# Altium schematic sheet Main_Board_NFC.SchDoc
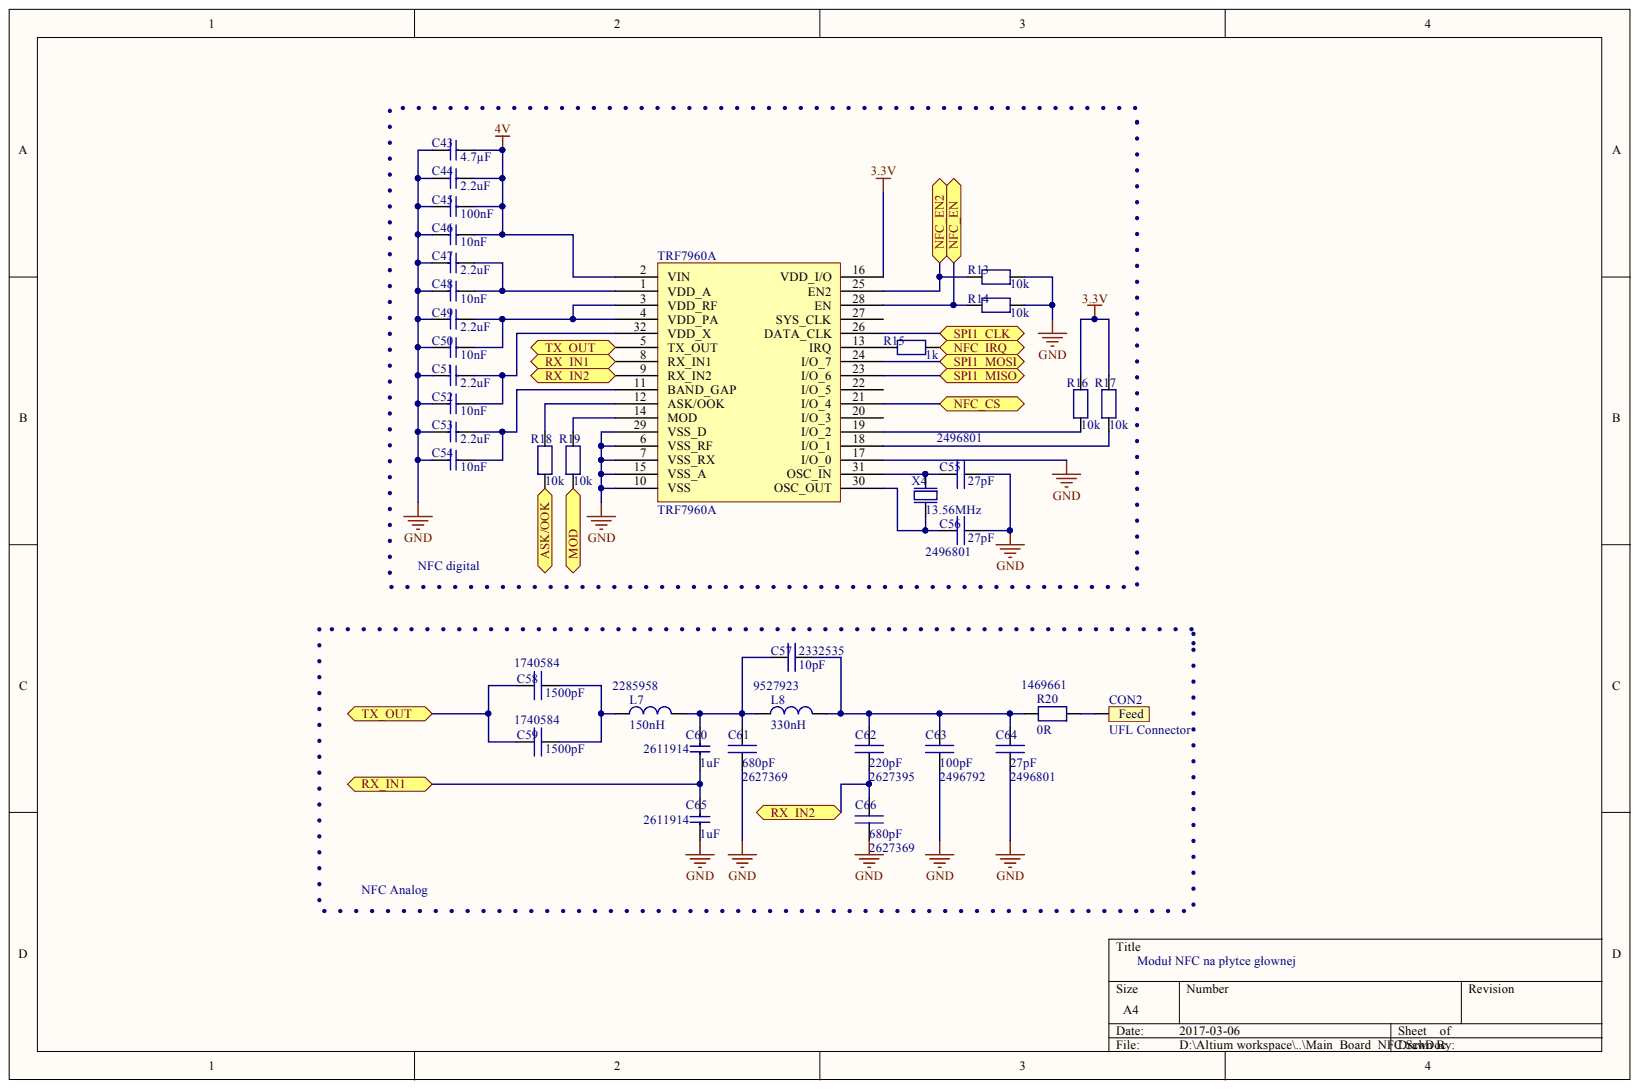
[diagram p0: Main_Board_NFC.SchDoc]

## Parts
C43  4.7µF  [CAPC1608X06L]
C44  2.2uF  value 4.7µF  [CAPC1608X06L]
C45  100nF  [CAPC0603X03L]
C46  10nF  value 100nF  [CAPC0603X03L]
C47  2.2uF  [CAPC1608X06L]
C48  10nF  [CAPC0603X03L]
C49  2.2uF  [CAPC1608X06L]
C50  10nF  [CAPC0603X03L]
C51  2.2uF  [CAPC1608X06L]
C52  10nF  [CAPC0603X03L]
C53  2.2uF  [CAPC1608X06L]
C54  10nF  [CAPC0603X03L]
C55  27pF  Supplier Part Number 1: 2496801  [0402]
C56  27pF  Supplier Part Number 1: 2496801  [0402]
C57  10pF  Supplier Part Number 1: 2332535  [0402]
C58  1500pF  Supplier Part Number 1: 1740584  [0402]
C59  1500pF  Supplier Part Number 1: 1740584  [0402]
C60  1uF  Supplier Part Number 1: 2611914  [CAPC1608X06L]
C61  680pF  Supplier Part Number 1: 2627369  [0402]
C62  220pF  Supplier Part Number 1: 2627395  [0402]
C63  100pF  Supplier Part Number 1: 2496792  [0402]
C64  27pF  Supplier Part Number 1: 2496801  [0402]
C65  1uF  Supplier Part Number 1: 2611914  [CAPC1608X06L]
C66  680pF  Supplier Part Number 1: 2627369  [0402]
CON2  UFL Connector  [UFL SMD]
L7  150nH  Supplier Part Number 1: 2285958  [0603]
L8  330nH  Supplier Part Number 1: 9527923  [0805]
R13  value 10k  [RES_0402]  (hidden comment: RES_0402_1k)
R14  value 10k  [RES_0402]  (hidden comment: RES_0402_1k)
R15  value 1k  [RES_0402]  (hidden comment: RES_0402_1k)
R16  value 10k  [RES_0402]  (hidden comment: RES_0402_1k)
R17  value 10k  [RES_0402]  (hidden comment: RES_0402_1k)
R18  value 10k  [RES_0402]  (hidden comment: RES_0402_1k)
R19  value 10k  [RES_0402]  (hidden comment: RES_0402_1k)
R20  value 0R  Supplier Part Number 2: 1469661  [RES_0402]  (hidden comment: RES_0402_1k)
TRF7960A  TRF7960A  [RHB32]
X4  13.56MHz  [BT-XTAL_2016]

## Ports
ASK/OOK, MOD, NFC_CS, NFC_EN, NFC_EN2, NFC_IRQ, RX_IN1, RX_IN2, SPI1_CLK, SPI1_MISO, SPI1_MOSI, TX_OUT

## Nets
3.3V: R16.2 R17.2 TRF7960A.16(VDD_I/O)
4V: C43.2 C44.1 C45.2 C46.2 TRF7960A.2(VIN)
GND: C43.1 C44.2 C45.1 C46.1 C47.2 C48.1 C49.2 C50.1 C51.2 C52.1 C53.2 C54.1 C55.1 C56.1 C61.1 C63.1 C64.2 C65.2 C66.1 CON2.2 R13.1 R14.1 TRF7960A.6(VSS_RF) TRF7960A.7(VSS_RX) TRF7960A.10(VSS) TRF7960A.15(VSS_A) TRF7960A.17(I/O_0) TRF7960A.29(VSS_D) X4.2 X4.4
ASK/OOK: R18.1 ; port ASK/OOK
MOD: R19.1 ; port MOD
NFC_CS: TRF7960A.21(I/O_4) ; port NFC_CS
NFC_EN: R14.2 TRF7960A.28(EN) ; port NFC_EN
NFC_EN2: R13.2 TRF7960A.25(EN2) ; port NFC_EN2
NFC_IRQ: R15.2 ; port NFC_IRQ
RX_IN1: C60.2 C65.1 TRF7960A.8(RX_IN1) ; port RX_IN1
RX_IN2: C62.1 C66.2 TRF7960A.9(RX_IN2) ; port RX_IN2
SPI1_CLK: TRF7960A.26(DATA_CLK) ; port SPI1_CLK
SPI1_MISO: TRF7960A.23(I/O_6) ; port SPI1_MISO
SPI1_MOSI: TRF7960A.24(I/O_7) ; port SPI1_MOSI
TX_OUT: C58.1 C59.1 TRF7960A.5(TX_OUT) ; port TX_OUT
NetC47_1: C47.1 C48.2 TRF7960A.1(VDD_A)
NetC49_1: C49.1 C50.2 TRF7960A.3(VDD_RF) TRF7960A.4(VDD_PA)
NetC51_1: C51.1 C52.2 TRF7960A.32(VDD_X)
NetC53_1: C53.1 C54.2 TRF7960A.11(BAND_GAP)
NetC55_2: C55.2 TRF7960A.31(OSC_IN) X4.3
NetC56_2: C56.2 TRF7960A.30(OSC_OUT) X4.1
NetC57_1: C57.1 C62.2 C63.2 C64.1 L8.2 R20.2
NetC57_2: C57.2 C60.1 C61.2 L7.2 L8.1
NetC58_2: C58.2 C59.2 L7.1
NetCON2_1: CON2.1 R20.1
NetR15_1: R15.1 TRF7960A.13(IRQ)
NetR16_1: R16.1 TRF7960A.19(I/O_2)
NetR17_1: R17.1 TRF7960A.18(I/O_1)
NetR18_2: R18.2 TRF7960A.12(ASK/OOK)
NetR19_2: R19.2 TRF7960A.14(MOD)
NetTRF7960A_20: TRF7960A.20(I/O_3)
NetTRF7960A_22: TRF7960A.22(I/O_5)
NetTRF7960A_27: TRF7960A.27(SYS_CLK)

## Library data of the parts
C43 C44 = C0603-4µ7: Capacitor, X5R, ±10%; Artikkelnr Noca: 20069060; Artikkelnr Simpro: N.A.; Database Table Name: Capacitors$; Note: 6.3V; Component Kind: Standard; Footprint Doc: 0603; LatestRevisionDate: 2009-11-23; Library Name: Nordic Passive DB.DbLib; Part Number: C0603-4µ7; Pin Count: 2; Published: 2009-05-08; Publisher: Nordic Semiconductor
  C43: Value: 4.7µF
C45 C46 = C0201-100n: Capacitor, X7R, ±10%; Artikkelnr Noca: N.A.; Database Table Name: Capacitors$; Note: 16V; Artikkelnr Simpro: N.A.; Component Kind: Standard; Footprint Doc: 0201; LatestRevisionDate: 2014-11-13; Library Name: Nordic Passive DB.DbLib; Part Number: C0201-100n; Pin Count: 2; Published: 2014-11-13; Publisher: Nordic Semiconductor
  C45: Value: 100nF
C47 C49 C51 C53 C60 C65 = C0603-4µ7: Capacitor, X5R, ±10%; Artikkelnr Noca: 20069060; Artikkelnr Simpro: N.A.; Database Table Name: Capacitors$; Note: 6.3V; Value: 4.7µF; Component Kind: Standard; Footprint Doc: 0603; LatestRevisionDate: 2009-11-23; Library Name: Nordic Passive DB.DbLib; Part Number: C0603-4µ7; Pin Count: 2; Published: 2009-05-08; Publisher: Nordic Semiconductor
  C60 C65: Supplier 1: Farnell
C48 C50 C52 C54 = C0201-100n: Capacitor, X7R, ±10%; Artikkelnr Noca: N.A.; Database Table Name: Capacitors$; Note: 16V; Value: 100nF; Artikkelnr Simpro: N.A.; Component Kind: Standard; Footprint Doc: 0201; LatestRevisionDate: 2014-11-13; Library Name: Nordic Passive DB.DbLib; Part Number: C0201-100n; Pin Count: 2; Published: 2014-11-13; Publisher: Nordic Semiconductor
C55-C59 C61-C64 C66 = Capacitor_MLCC: ?; Component Kind: Standard; Library Name: Capacitors.SchLib; Supplier 1: Farnell
L7 L8 = Inductor: Component Kind: Standard; Library Name: Inductors.SchLib; Supplier 1: Farnell
X4 = XTAL_SMD2016_32MHz_8pF_Epson: XTAL SMD 2016, 32MHz, Cl=8pF, Total Tol: ±40ppm; Manufacturer: Epson Toyocom; Manufacturer Part Number: Q22FA12800025; Artikkelnr Noca: N.A.; Database Table Name: Crystal_Osc$; Note: XTAL SMD 32.000MHz; Artikkelnr Simpro: N.A.; Component Kind: Standard; Datasheet: http://www.epsondevice.com/docs/qd/en/DownloadServlet?id=ID000449; Footprint Doc: XTAL_2016; LatestRevisionDate: 2014-11-27; Library Name: Nordic Discrete DB.DbLib; Part Number: XTAL_SMD2016_32MHz_8pF_Epson; Pin Count: 4; Published: 2012-03-09; Supplier: Farnell; Supplier part number: 171-2806; Value: 32MHz; Publisher: Nordic Semiconductor
CON2 = UFL Connector: Component Kind: Standard; Supplier 1: Digi-Key; Supplier 2: Digi-Key; Supplier 3: Digi-Key; Supplier 4: Digi-Key; Supplier 5: Digi-Key; Supplier 6: Digi-Key; Supplier 7: Farnell; Supplier 8: Farnell; Supplier Part Number 1: H9161DKR-ND; Supplier Part Number 2: H11891CT-ND; Supplier Part Number 3: H11891DKR-ND; Supplier Part Number 4: H9161CT-ND; Supplier Part Number 5: H9161TR-ND; Supplier Part Number 6: H11891TR-ND; Supplier Part Number 7: 1688077; Supplier Part Number 8: 1688077RL; Library Name: ONEMETER.SchLib
R13-R20 = RES_0402_1k: Component Kind: Standard; Supplier 2: Farnell; Library Name: ONEMETER.SchLib
  R13-R19: Supplier 1: Digi-Key; Supplier 3: Mouser; Supplier Part Number 1: 311-1.0KJRTR-ND; Supplier Part Number 2: 1358043; Supplier Part Number 3: 603-RC0402FR-071KL
  R20: Supplier 1: Mouser; Supplier Part Number 1: 603-RC0402FR-071KL
TRF7960A = TRF7960A: Component Kind: Standard; Library Name: NFC.SchLib
